# Altium schematic sheet RP2040.SchDoc
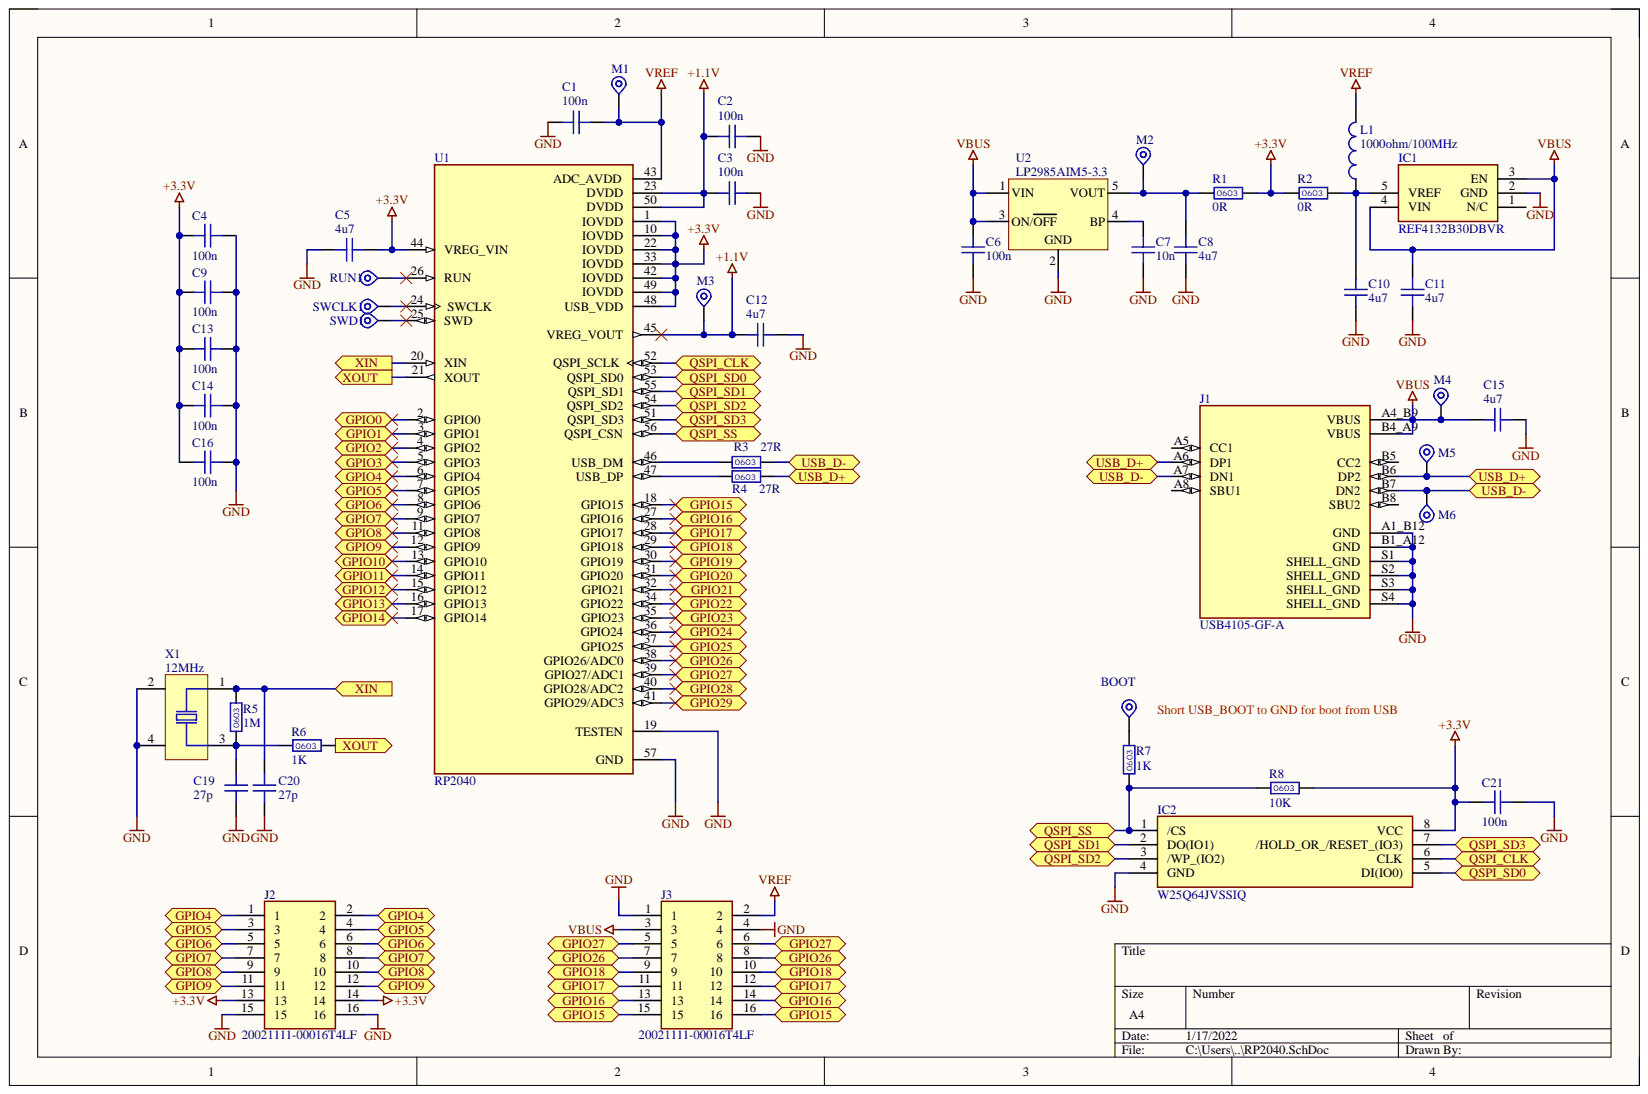
[diagram p0: RP2040.SchDoc]

## Parts
BOOT  TP  [Pad0.6mm]  (value not drawn)  (no BOM)
C1  100n  [C0603M]
C2  100n  [C0603M]
C3  100n  [C0603M]
C4  100n  [C0603M]
C5  4u7  [C0603]
C6  100n  [C0603M]
C7  10n  [C0603M]
C8  4u7  [C0603]
C9  100n  [C0603M]
C10  4u7  [C0603]
C11  4u7  [C0603]
C12  4u7  [C0603]
C13  100n  [C0603M]
C14  100n  [C0603M]
C15  4u7  [C0603]
C16  100n  [C0603M]
C19  27p  [C0603M]
C20  27p  [C0603M]
C21  100n  [C0603M]
IC1  REF4132B30DBVR  [SOT95P280X145-5N]
IC2  W25Q64JVSSIQ  [SOIC127P790X216-8N]
J1  USB4105-GF-A  [GCT_USB4105-GF-A]
J2  20021111-00016T4LF  [2002111100016T4LF]
J3  20021111-00016T4LF  [2002111100016T4LF]
L1  1000ohm/100MHz  [L0805M]
M1  TP  [Pad 1mm SMD]  (value not drawn)  (no BOM)
M2  TP  [Pad 1mm SMD]  (value not drawn)  (no BOM)
M3  TP  [Pad 1mm SMD]  (value not drawn)  (no BOM)
M4  TP  [Pad 1mm SMD]  (value not drawn)  (no BOM)
M5  TP  [Pad 1mm SMD]  (value not drawn)  (no BOM)
M6  TP  [Pad 1mm SMD]  (value not drawn)  (no BOM)
R1  0R  [R0603]
R2  0R  [R0603]
R3  27R  [R0603]
R4  27R  [R0603]
R5  1M  [R0603]
R6  1K  [R0603]
R7  1K  [R0603]
R8  10K  [R0603]
RUN1  TP  [Pad0.6mm]  (value not drawn)  (no BOM)
SWCLK1  TP  [Pad0.6mm]  (value not drawn)  (no BOM)
SWD1  TP  [Pad0.6mm]  (value not drawn)  (no BOM)
U1  RP2040  [QFN40P700X700X90-57N]
U2  LP2985AIM5-3.3  [SOT23-5]
X1  12MHz  [XTAL_SMD_5032_4PIN]

## Ports
GPIO0, GPIO1, GPIO2, GPIO3, GPIO4, GPIO5, GPIO6, GPIO7, GPIO8, GPIO9, GPIO10, GPIO11, GPIO12, GPIO13, GPIO14, GPIO15, GPIO16, GPIO17, GPIO18, GPIO19, GPIO20, GPIO21, GPIO22, GPIO23, GPIO24, GPIO25, GPIO26, GPIO27, GPIO28, GPIO29, QSPI_CLK, QSPI_SD0, QSPI_SD1, QSPI_SD2, QSPI_SD3, QSPI_SS, USB_D+, USB_D-, XIN, XOUT

## Nets
+1.1V: C2.1 C3.1 C12.1 M3.1 U1.23(DVDD) U1.45(VREG_VOUT) U1.50(DVDD)
+3.3V: C4.2 C5.2 C9.2 C13.2 C14.2 C16.2 C21.1 IC2.8(VCC) J2.13 J2.14 R1.1 R2.2 R8.1 U1.1(IOVDD) U1.10(IOVDD) U1.22(IOVDD) U1.33(IOVDD) U1.42(IOVDD) U1.44(VREG_VIN) U1.48(USB_VDD) U1.49(IOVDD)
GND: C1.2 C2.2 C3.2 C4.1 C5.1 C6.2 C7.2 C8.2 C9.1 C10.2 C11.2 C12.2 C13.1 C14.1 C15.2 C16.1 C19.2 C20.2 C21.2 IC1.2(GND) IC2.4(GND) J1.A1_B12(GND) J1.B1_A12(GND) J1.S1(SHELL_GND) J1.S2(SHELL_GND) J1.S3(SHELL_GND) J1.S4(SHELL_GND) J2.15 J2.16 J3.1 J3.4 U1.19(TESTEN) U1.57(GND) U2.2 X1.2 X1.4
VBUS: C6.1 C11.1 C15.1 IC1.3(EN) IC1.4(VIN) J1.A4_B9(VBUS) J1.B4_A9(VBUS) J3.3 M4.1 U2.1(VIN) U2.3(ON/OFF)
VREF: C1.1 J3.2 L1.2 M1.1 U1.43(ADC_AVDD)
GPIO0: U1.2(GPIO0) ; port GPIO0
GPIO1: U1.3(GPIO1) ; port GPIO1
GPIO2: U1.4(GPIO2) ; port GPIO2
GPIO3: U1.5(GPIO3) ; port GPIO3
GPIO4: J2.1 J2.2 U1.6(GPIO4) ; port GPIO4
GPIO5: J2.3 J2.4 U1.7(GPIO5) ; port GPIO5
GPIO6: J2.5 J2.6 U1.8(GPIO6) ; port GPIO6
GPIO7: J2.7 J2.8 U1.9(GPIO7) ; port GPIO7
GPIO8: J2.9 J2.10 U1.11(GPIO8) ; port GPIO8
GPIO9: J2.11 J2.12 U1.12(GPIO9) ; port GPIO9
GPIO10: U1.13(GPIO10) ; port GPIO10
GPIO11: U1.14(GPIO11) ; port GPIO11
GPIO12: U1.15(GPIO12) ; port GPIO12
GPIO13: U1.16(GPIO13) ; port GPIO13
GPIO14: U1.17(GPIO14) ; port GPIO14
GPIO15: J3.15 J3.16 U1.18(GPIO15) ; port GPIO15
GPIO16: J3.13 J3.14 U1.27(GPIO16) ; port GPIO16
GPIO17: J3.11 J3.12 U1.28(GPIO17) ; port GPIO17
GPIO18: J3.9 J3.10 U1.29(GPIO18) ; port GPIO18
GPIO19: U1.30(GPIO19) ; port GPIO19
GPIO20: U1.31(GPIO20) ; port GPIO20
GPIO21: U1.32(GPIO21) ; port GPIO21
GPIO22: U1.34(GPIO22) ; port GPIO22
GPIO23: U1.35(GPIO23) ; port GPIO23
GPIO24: U1.36(GPIO24) ; port GPIO24
GPIO25: U1.37(GPIO25) ; port GPIO25
GPIO26: J3.7 J3.8 U1.38(GPIO26/ADC0) ; port GPIO26
GPIO27: J3.5 J3.6 U1.39(GPIO27/ADC1) ; port GPIO27
GPIO28: U1.40(GPIO28/ADC2) ; port GPIO28
GPIO29: U1.41(GPIO29/ADC3) ; port GPIO29
QSPI_CLK: IC2.6(CLK) U1.52(QSPI_SCLK) ; port QSPI_CLK
QSPI_SD0: IC2.5(DI(IO0)) U1.53(QSPI_SD0) ; port QSPI_SD0
QSPI_SD1: IC2.2(DO(IO1)) U1.55(QSPI_SD1) ; port QSPI_SD1
QSPI_SD2: IC2.3(/WP_(IO2)) U1.54(QSPI_SD2) ; port QSPI_SD2
QSPI_SD3: IC2.7(/HOLD_OR_/RESET_(IO3)) U1.51(QSPI_SD3) ; port QSPI_SD3
QSPI_SS: IC2.1(/CS) R7.2 R8.2 U1.56(QSPI_CSN) ; port QSPI_SS
XIN: C20.1 R5.1 U1.20(XIN) X1.1 ; port XIN
XOUT: R6.1 U1.21(XOUT) ; port XOUT
NetBOOT_1: BOOT.1 R7.1
NetC7_1: C7.1 U2.4(BP)
NetC8_1: C8.1 M2.1 R1.2 U2.5(VOUT)
NetC10_1: C10.1 IC1.5(VREF) L1.1 R2.1
NetC19_1: C19.1 R5.2 R6.2 X1.3
NetJ1_A6: J1.A6(DP1) J1.B6(DP2) M5.1 R4.1
NetJ1_A7: J1.A7(DN1) J1.B7(DN2) M6.1 R3.1
NetR3_2: R3.2 U1.46(USB_DM)
NetR4_2: R4.2 U1.47(USB_DP)
NetRUN1_1: RUN1.1 U1.26(RUN)
NetSWCLK1_1: SWCLK1.1 U1.24(SWCLK)
NetSWD1_1: SWD1.1 U1.25(SWD)

Not connected: IC1 1(N/C); J1 A5(CC1) A8(SBU1) B5(CC2) B8(SBU2)

## Library data of the parts
BOOT M1-M6 RUN1 SWCLK1 SWD1 = M Point: Test Point; Main: Mechanical; Category: Pads_Pins_Measure_Points; Library Name: PCB_RP2040.SCHLIB; Stock number: --------
C1-C4 C6 C9 C13 C14 C16 C21 = UniversalCapacitor: Ceramic Capacitor X7R; Manufacturer: VISHAY; Part Number: 100n/16V X7R C0603; Main: Capacitors; SMD/THD: SMD; Value: 100n/16V; Category: Capacitors CERA SMD; Component Kind: Standard; Library Name: PCB_RP2040.SCHLIB; Status: X; Stock number: 10003450
C5 C10-C12 C15 = UniversalCapacitor: Ceramic Capacitor X5R; Manufacturer: Murata; Part Number: GRM188R60J475KE19J; Main: Capacitors; SMD/THD: SMD; Value: 4u7/6,3V; Category: Capacitors CERA SMD; Component Kind: Standard; ComponentLink1Description: Datasheet; ComponentLink1URL: http://datasheet.octopart.com/GRM188R60J475KE19J-Murata-datasheet-14401031.pdf; Library Name: PCB_RP2040.SCHLIB; Status: T; Stock number: 10006168
C7 = UniversalCapacitor: Ceramic Capacitor X7R; Manufacturer: VISHAY; Part Number: 10n/50V X7R C0603; Main: Capacitors; SMD/THD: SMD; Category: Capacitors CERA SMD; Component Kind: Standard; Library Name: PCB_RP2040.SCHLIB; Status: A; Stock number: 10002497; Value: 10n
C8 = CMP-UN-000401-1: Ceramic Capacitor X5R; Manufacturer: Murata; Part Number: GRM188R60J475KE19J; Main: Capacitors; SMD/THD: SMD; Value: 4u7/6,3V; Category: Capacitors CERA SMD; Component Kind: Standard; ComponentLink1Description: Datasheet; ComponentLink1URL: http://datasheet.octopart.com/GRM188R60J475KE19J-Murata-datasheet-14401031.pdf; Status: T; Stock number: 10006168; Library Name: UNIS
C19 C20 = UniversalCapacitor: Ceramic Capacitor NPO; Manufacturer: VISHAY; Part Number: 22p/50V NPO C0603; Main: Capacitors; SMD/THD: SMD; Value: 22p; Category: Capacitors CERA SMD; Component Kind: Standard; Library Name: PCB_RP2040.SCHLIB; Status: A; Stock number: 10004609
IC1 = REF4132B30DBVR: Integrated Circuit; Manufacturer_Name: Texas Instruments; Component Kind: Standard; Datasheet Link: https://www.ti.com/lit/ds/symlink/ref4132.pdf?ts=1621337373445&ref_url=https%253A%252F%252Fwww.ti.com%252Fstore%252Fti%252Fen%252Fp%252Fproduct%252F%253Fp%253DREF4132B33DBVR; Height: 1.45mm; Manufacturer_Part_Number: REF4132B30DBVR
IC2 = W25Q64JVSSIQ: Integrated Circuit; Manufacturer_Name: Winbond; Component Kind: Standard; Datasheet Link: https://componentsearchengine.com//W25Q64JVSSIQ.pdf; Height: 2.16mm; Manufacturer_Part_Number: W25Q64JVSSIQ; Mouser2 Part Number: 454-W25Q64JVSSIQ; Mouser2 Price/Stock: https://www.mouser.com/Search/Refine.aspx?Keyword=454-W25Q64JVSSIQ
J1 = USB4105-GF-A: USB-C (USB TYPE-C) USB 2.0 Receptacle Connector 24 (16+8 Dummy) Position Surface Mount, Right Angle; Through Hole; MF: GCT; Availability: Warning; Check_prices: https://www.snapeda.com/parts/USB4105-GF-A/Global%20Connector%20Technology/view-part/4423780/?ref=eda; Component Kind: Standard; Library Name: USB4105-GF-A.IntLib; MP: USB4105-GF-A; Package: None; Price: None; Purchase-URL: https://pricing.snapeda.com/search/part/USB4105-GF-A/?ref=eda; SnapEDA_Link: https://www.snapeda.com/parts/USB4105-GF-A/Global%20Connector%20Technology/view-part/4423780/?ref=snap
J2 J3 = 20021111-00016T4LF: Connector; Manufacturer_Name: Amphenol; Component Kind: Standard; Datasheet Link: https://cdn.amphenol-icc.com/media/wysiwyg/files/drawing/20021111.pdf; Height: 5.5mm; Manufacturer_Part_Number: 20021111-00016T4LF
L1 = UniversalInductor: Ferrite Bead (10 to 200MHz) 0.5A; Manufacturer: TDK; Part Number: MMZ2012R102A; Main: Inductors; SMD/THD: SMD; Category: Ferrite Beads; Component Kind: Standard; ComponentLink1Description: Datasheet; ComponentLink1URL: http://www.farnell.com/datasheets/84901.pdf; Library Name: PCB_RP2040.SCHLIB; Status: A; Stock number: 10005111; Value: 1000ohm/100MHz
R1 = CMP-UN-002043-2: Resistor 1%, 0.1W, 75V; Manufacturer: VISHAY; Part Number: 0R R0603; Main: Resistors; SMD/THD: SMD; Category: Resistors SMD; Component Kind: Standard; Status: A; Stock number: 10005433; Value: 0R; Library Name: UNIS
R2 = UniversalResistor: Resistor 1%, 0.1W, 75V; Manufacturer: VISHAY; Part Number: 0R R0603; Main: Resistors; SMD/THD: SMD; Category: Resistors SMD; Component Kind: Standard; Library Name: PCB_RP2040.SCHLIB; Status: A; Stock number: 10005433; Value: 0R
R3 R4 = UniversalResistor: Resistor 1%, 0.1W, 75V; Manufacturer: YAGEO (PHYCOMP); Part Number: 27R R0603; Main: Resistors; SMD/THD: SMD; Category: Resistors SMD; Component Kind: Standard; Library Name: RP2040.SchLib; Status: A; Stock number: 10005475; Value: 27R
R5 = UniversalResistor: Resistor 1%, 0.1W, 75V; Manufacturer: VISHAY; Part Number: 1M0 R0603; Main: Resistors; SMD/THD: SMD; Category: Resistors SMD; Component Kind: Standard; Library Name: PCB_RP2040.SCHLIB; Status: A; Stock number: 10004745; Value: 1M
R6 R7 = UniversalResistor: Resistor 1%, 0.1W, 75V; Manufacturer: VISHAY; Part Number: 1K R0603; Main: Resistors; SMD/THD: SMD; Category: Resistors SMD; Component Kind: Standard; Library Name: PCB_RP2040.SCHLIB; Status: A; Stock number: 10004641; Value: 1K
R8 = UniversalResistor: Resistor 1%, 0.1W, 75V; Manufacturer: VISHAY; Part Number: 10K R0603; Main: Resistors; SMD/THD: SMD; Category: Resistors SMD; Component Kind: Standard; Library Name: PCB_RP2040.SCHLIB; Status: A; Stock number: 10004622; Value: 10K
U1 = RP2040: RP2040 Raspberry Pi Pico - ARMÂ® CortexÂ®-M0+ MCU 32-Bit Embedded Evaluation Board; Availability: In Stock; Check_prices: https://www.snapeda.com/parts/RP2040/Raspberry%20Pi/view-part/5800506/?ref=eda; Component Kind: Standard; Library Name: RP2040.SchLib; MF: Raspberry Pi; MP: RP2040; Package: Package; Price: None; Purchase-URL: https://pricing.snapeda.com/search/part/RP2040/?ref=eda; SnapEDA_Link: https://www.snapeda.com/parts/RP2040/Raspberry%20Pi/view-part/5800506/?ref=snap
U2 = LP2985_SOT25: 150-mA , Low-Dropout Regulator; Manufacturer: Texas Instruments; Part Number: LP2985AIM5-3.3/NOPB; Main: IC's; SMD/THD: SMD; Category: Voltage Regulators; Component Kind: Standard; ComponentLink1Description: Datasheet; ComponentLink1URL: http://www.farnell.com/datasheets/1972829.pdf; Library Name: PCB_RP2040.SCHLIB; Status: N; Stock number: 10006099; Value: LP2985AIM5-3.3
X1 = Symbol: Crystal Resonator; Manufacturer: Geyer; Part Number: 12.000MHz KX-9A; Main: Crystals; Category: Crystals; Component Kind: Standard; Library Name: PCB_RP2040.SCHLIB; Value: 12MHz
